# Altium schematic sheet DAC.SchDoc(DAC)
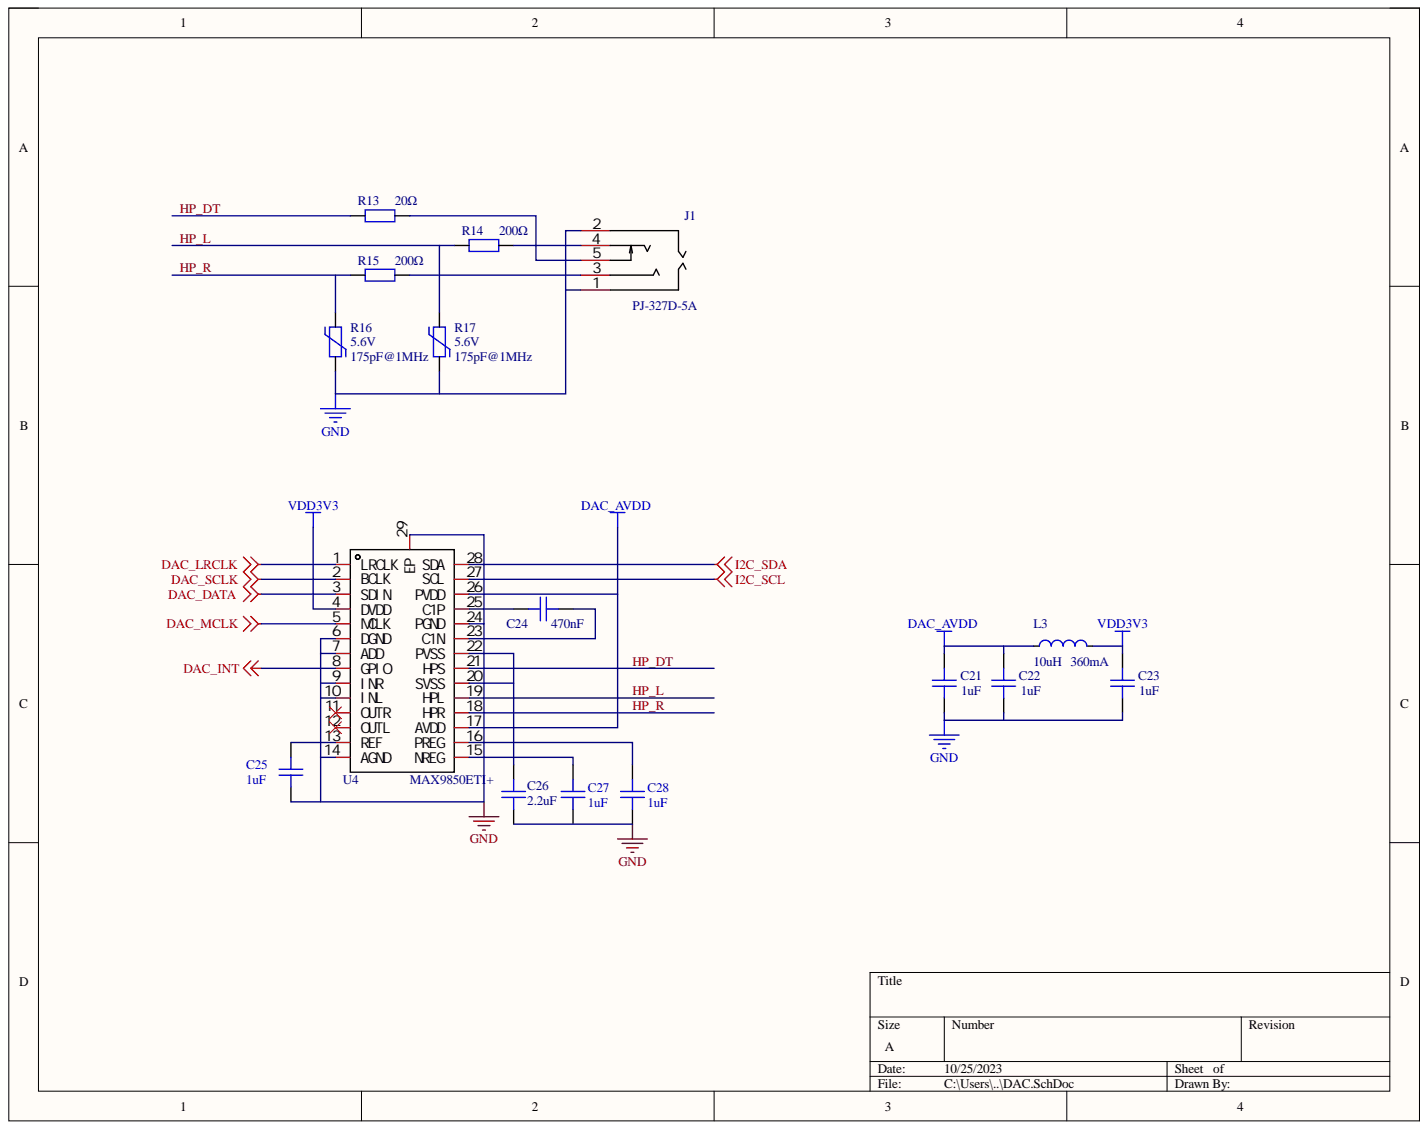
[diagram p0: DAC.SchDoc]

## Parts
C21  value 1uF  [C0603]
C22  value 1uF  [C0603]
C23  value 1uF  [C0603]
C24  value 470nF  [C0603]
C25  value 1uF  [C0603]
C26  value 2.2uF  [C0603]
C27  value 1uF  [C0603]
C28  value 1uF  [C0603]
J1  PJ-327D-5A  [AUDIO-TH_PJ-327D-5A]
L3  value 10uH  [L0805]
R13  value 20Ω  [R0402]
R14  value 200Ω  [R0402]
R15  value 200Ω  [R0402]
R16  Allowable Voltage (DC): 5.6V  [R0402]
R17  Allowable Voltage (DC): 5.6V  Typical Capatitance: 175pF@1MHz  [R0402]
U4  MAX9850ETI+  [QFN-28_L5.0-W5.0-P0.50-TL-EP3.5]

## Nets
DAC_AVDD: C21.2 C22.2 L3.1 U4.17(AVDD) U4.26(PVDD)
DAC_DATA: U4.3(SDIN)
DAC_INT: U4.8(GPIO)
DAC_LRCLK: U4.1(LRCLK)
DAC_MCLK: U4.5(MCLK)
DAC_SCLK: U4.2(BCLK)
GND: C21.1 C22.1 C23.1 C25.2 C26.1 C27.2 C28.2 J1.1 J1.2 R16.2 R17.2 U4.6(DGND) U4.7(ADD) U4.9(INR) U4.10(INL) U4.14(AGND) U4.24(PGND) U4.29(EP)
I2C_SCL: U4.27(SCL)
I2C_SDA: U4.28(SDA)
VDD3V3: C23.2 L3.2 U4.4(DVDD)
HP_DT: R13.1 U4.21(HPS)
HP_L: R14.1 R17.1 U4.19(HPL)
HP_R: R15.1 R16.1 U4.18(HPR)
NetC24_1: C24.1 U4.25(C1P)
NetC24_2: C24.2 U4.23(C1N)
NetC25_1: C25.1 U4.13(REF)
NetC26_2: C26.2 U4.20(SVSS) U4.22(PVSS)
NetC27_1: C27.1 U4.15(NREG)
NetC28_1: C28.1 U4.16(PREG)
NetJ1_3: J1.3 R15.2
NetJ1_4: J1.4 R14.2
NetJ1_5: J1.5 R13.2

Not connected: U4 11(OUTR) 12(OUTL)

## Library data of the parts
C21-C28 = CL05A105KA5NQNC: Manufacturer: SAMSUNG(三星); Add into BOM: yes; Temperature Coefficient: X5R; Tolerance: ±10%; Voltage Rated: 25V; Component Kind: Standard; Convert to PCB: yes; Datasheet: https://atta.szlcsc.com/upload/public/pdf/source/20160103/1457707735223.pdf; Device: CL05A105KA5NQNC; JLCPCB Part Class: Basic Part; LCSC Part Name: 1uF ±10% 25V; Manufacturer Part: CL05A105KA5NQNC; PCB3D: C0402_L1.0-W0.5-H0.5; Supplier: LCSC; Supplier Footprint: 0402; Supplier Part: C52923; Symbol: CL05A105KA5NQNC
J1 = PJ-327D-5A: Manufacturer: 韩国韩荣; Add into BOM: yes; Connector Type: 3.5mm耳机座; Current Rating (Max): 500mA; Voltage Rating (Max): 30V; Component Kind: Standard; Convert to PCB: yes; Datasheet: https://atta.szlcsc.com/upload/public/pdf/source/20200721/C707229_B311DBB588EE2F7889DC136D68160FBA.pdf; Device: PJ-327D-5A; JLCPCB Part Class: Extended Part; LCSC Part Name: 3.5mm耳机座; Manufacturer Part: PJ-327D-5A; Name: PJ-327D-5A; Operating Temperature Range: -20℃~+70℃; PCB3D: AUDIO-TH_PJ-327D-5A; Supplier: LCSC; Supplier Footprint: 插件; Supplier Part: C707229; Symbol: PJ-327D-5A
L3 = SLM2012100MIT: Manufacturer: Sunltech(韩国顺磁); Add into BOM: yes; DC Resistance (DCR): 550mΩ; Rated Current: 360mA; Tolerance: ±20%; Component Kind: Standard; Convert to PCB: yes; Datasheet: https://atta.szlcsc.com/upload/public/pdf/source/20180528/C216156_746607D01E5EB4C50E0D2BE40685D2FE.pdf; Device: SLM2012100MIT; JLCPCB Part Class: Extended Part; LCSC Part Name: 10uH ±20% 360mA 550mΩ; Manufacturer Part: SLM2012100MIT; PCB3D: R0805_L2.0-W1.3-H0.6; Ratings: -; Saturation Current (Isat): -; Supplier: LCSC; Supplier Footprint: 0805; Supplier Part: C2831365; Symbol: SLM2012100MIT
R13-R15 = 0603WAF200JT5E: Manufacturer: UNI-ROYAL(厚声); Add into BOM: yes; Overload Voltage (Max): 75V; Power(Watts): 1/10W; Temperature Coefficient: ±100ppm/; Tolerance: ±1%; Component Kind: Standard; Convert to PCB: yes; Datasheet: https://atta.szlcsc.com/upload/public/pdf/source/20200306/C422600_1E6D84923E4A46A82E41ADD87F860B5C.pdf; Device: 0603WAF200JT5E; JLCPCB Part Class: Basic Part; LCSC Part Name: 20Ω ±1%; Manufacturer Part: 0603WAF200JT5E; Operating Temperature Range: -55~+155; PCB3D: R0603_L1.6-W0.8-H0.8; Supplier: LCSC; Supplier Footprint: 0603; Supplier Part: C22950; Symbol: 0603WAF200JT5E; Type: 厚膜电阻
R16 = VC040205X150WP: Manufacturer: AVX; Add into BOM: yes; Allowable Voltage (AC): 4V; Clamping Voltage: 18V; Maximum Energy: 0.05J; Peak Current: 20A; Typical Capatitance: 175pF@1MHz; Component Kind: Standard; Convert to PCB: yes; Datasheet: https://atta.szlcsc.com/upload/public/pdf/source/20191107/C444835_6DEC1386ECA04C59FB46CA24244FFD30.pdf; Device: VC040205X150WP; JLCPCB Part Class: Extended Part; LCSC Part Name: 5.6V 175pF; Manufacturer Part: VC040205X150WP; PCB3D: R0402_L1.0-W0.5-H0.5; Supplier: LCSC; Supplier Footprint: 0402; Supplier Part: C444835; Symbol: VC040205X150WP; Varistor Voltage: -
R17 = VC040205X150WP: Manufacturer: AVX; Add into BOM: yes; Allowable Voltage (AC): 4V; Clamping Voltage: 18V; Maximum Energy: 0.05J; Peak Current: 20A; Component Kind: Standard; Convert to PCB: yes; Datasheet: https://atta.szlcsc.com/upload/public/pdf/source/20191107/C444835_6DEC1386ECA04C59FB46CA24244FFD30.pdf; Device: VC040205X150WP; JLCPCB Part Class: Extended Part; LCSC Part Name: 5.6V 175pF; Manufacturer Part: VC040205X150WP; PCB3D: R0402_L1.0-W0.5-H0.5; Supplier: LCSC; Supplier Footprint: 0402; Supplier Part: C444835; Symbol: VC040205X150WP; Varistor Voltage: -
U4 = MAX9850ETI+: Manufacturer: MAXIM(美信); Add into BOM: yes; Component Kind: Standard; Convert to PCB: yes; Datasheet: https://atta.szlcsc.com/upload/public/pdf/source/20210625/C2832363_57678138495B4A14684B8489EFE18044.pdf; Device: MAX9850ETI+; JLCPCB Part Class: Extended Part; LCSC Part Name: MAX9850ETI+; Manufacturer Part: MAX9850ETI+; Name: MAX9850ETI+; Output Power: -; Supplier: LCSC; Supplier Footprint: QFN-28-EP(5x5); Supplier Part: C2832363; Symbol: MAX9850ETI+; Type of Power Amplifier: -
